# Altium schematic sheet PS3604LRCF.SchDoc(PS3604LRCF)
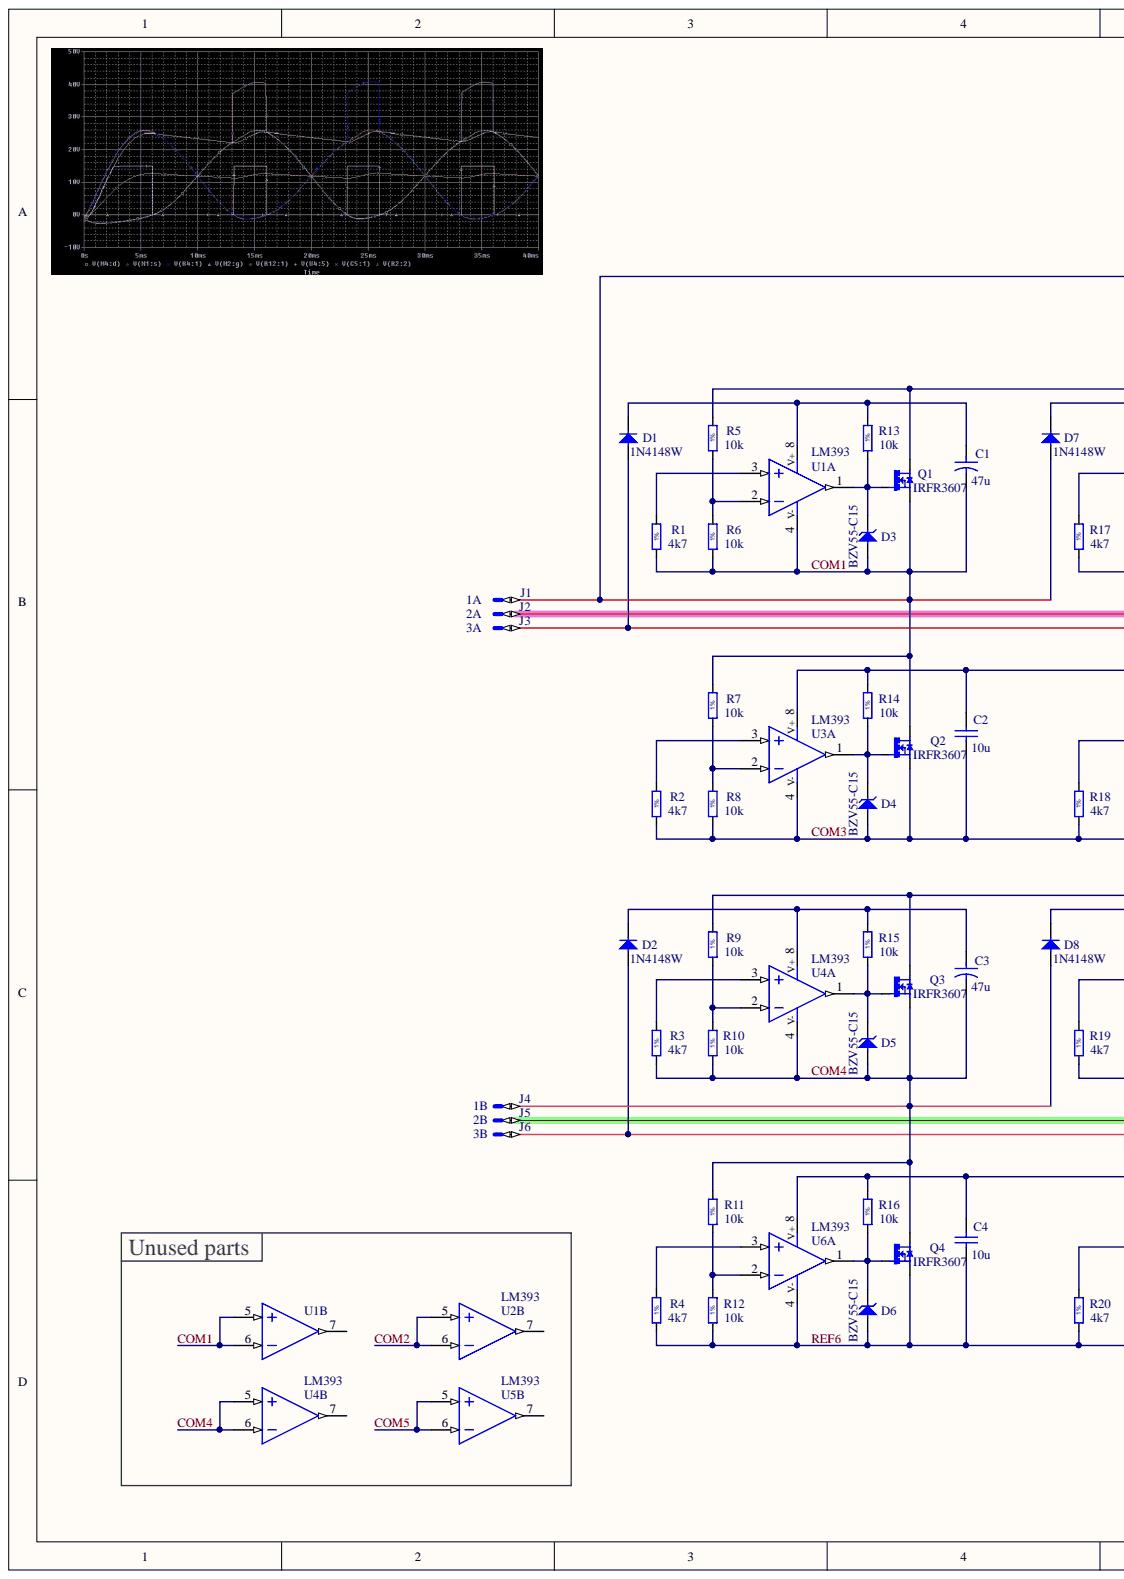
[diagram p0: PS3604LRCF.SchDoc, left]
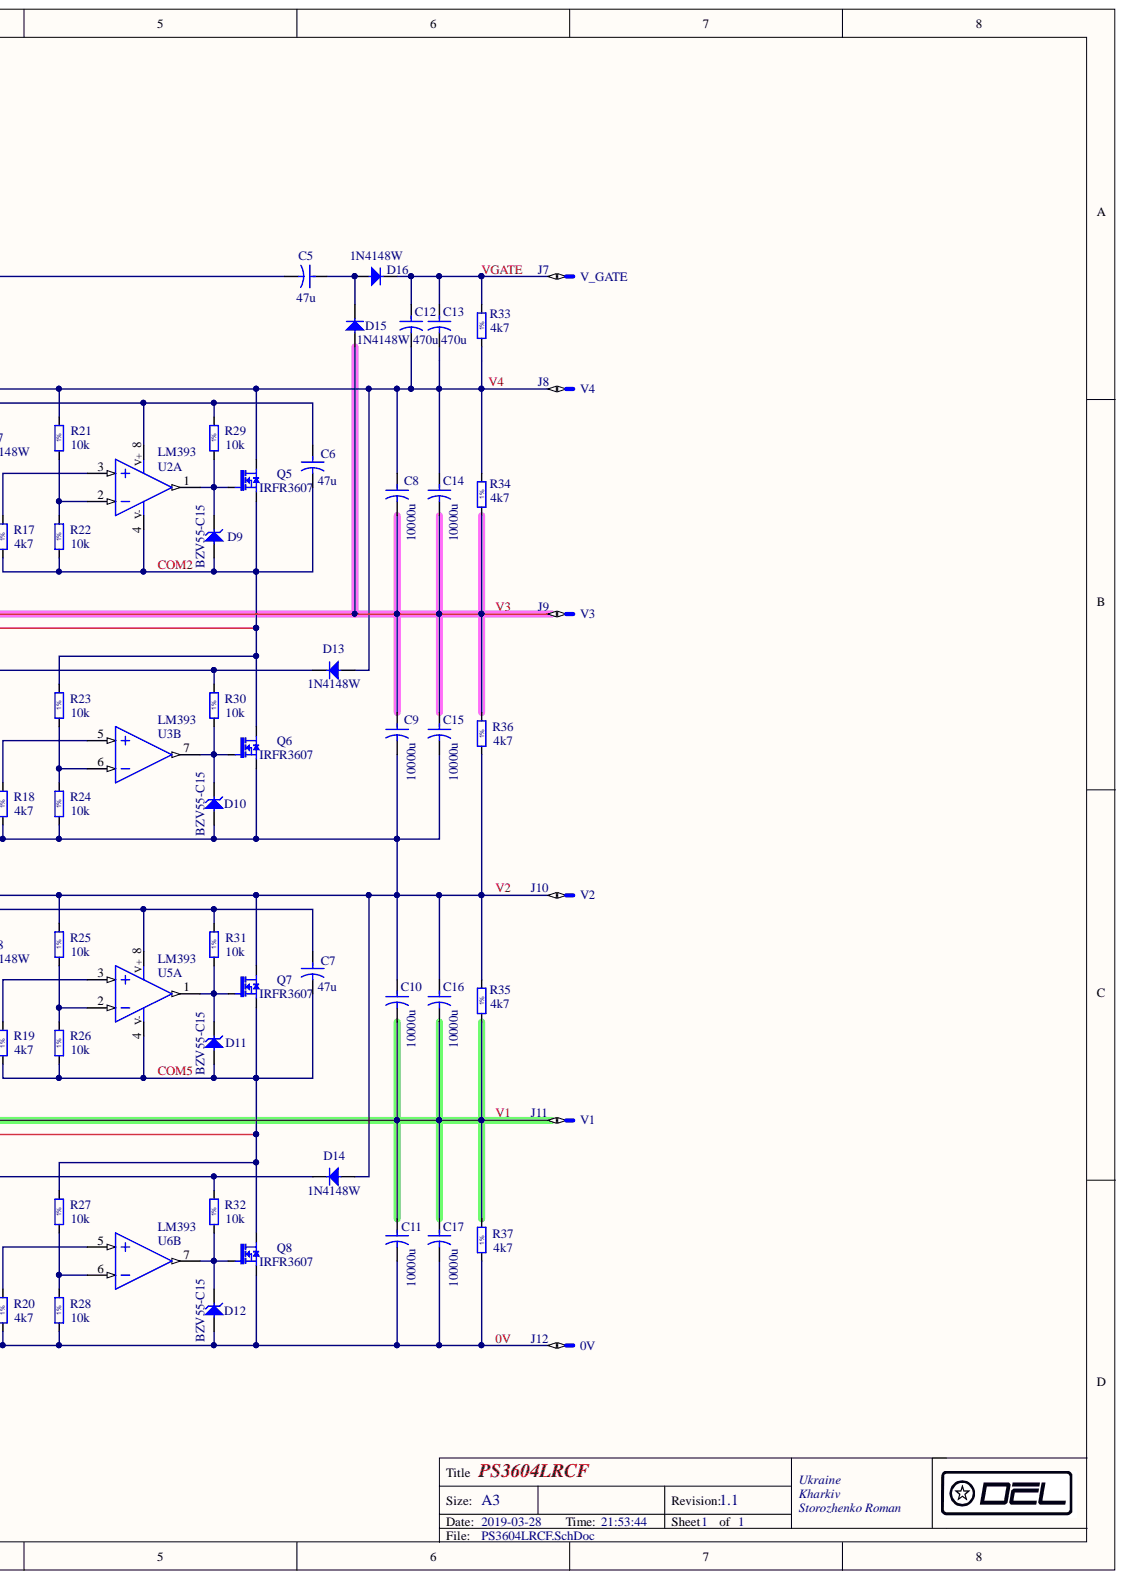
[diagram p1: PS3604LRCF.SchDoc, right]

## Parts
C1  47u  [CAPPR250-630X1120]
C2  10u  [CAPC2012X135N]
C3  47u  [CAPPR250-630X1120]
C4  10u  [CAPC2012X135N]
C5  47u  [CAPPR250-630X1120]
C6  47u  [CAPPR250-630X1120]
C7  47u  [CAPPR250-630X1120]
C8  10000u  [CAPPR750-1850X3750]
C9  10000u  [CAPPR750-1850X3750]
C10  10000u  [CAPPR750-1850X3750]
C11  10000u  [CAPPR750-1850X3750]
C12  470u  [CAPPR500-1050X2200]
C13  470u  [CAPPR500-1050X2200]
C14  10000u  [CAPPR750-1850X3750]
C15  10000u  [CAPPR750-1850X3750]
C16  10000u  [CAPPR750-1850X3750]
C17  10000u  [CAPPR750-1850X3750]
D1  1N4148W  [SOD3715X145N]
D2  1N4148W  [SOD3715X145N]
D3  BZV55-C15  [DIOMELF3515N]
D4  BZV55-C15  [DIOMELF3515N]
D5  BZV55-C15  [DIOMELF3515N]
D6  BZV55-C15  [DIOMELF3515N]
D7  1N4148W  [SOD3715X145N]
D8  1N4148W  [SOD3715X145N]
D9  BZV55-C15  [DIOMELF3515N]
D10  BZV55-C15  [DIOMELF3515N]
D11  BZV55-C15  [DIOMELF3515N]
D12  BZV55-C15  [DIOMELF3515N]
D13  1N4148W  [SOD3715X145N]
D14  1N4148W  [SOD3715X145N]
D15  1N4148W  [SOD3715X145N]
D16  1N4148W  [SOD3715X145N]
J1  Quixon™  [MOLEX_19_70_54203]  (value not drawn)
J2  Quixon™  [MOLEX_19_70_54203]  (value not drawn)
J3  Quixon™  [MOLEX_19_70_54203]  (value not drawn)
J4  Quixon™  [MOLEX_19_70_54203]  (value not drawn)
J5  Quixon™  [MOLEX_19_70_54203]  (value not drawn)
J6  Quixon™  [MOLEX_19_70_54203]  (value not drawn)
J7  Quixon™  [MOLEX_19_70_54203]  (value not drawn)
J8  Quixon™  [MOLEX_19_70_54203]  (value not drawn)
J9  Quixon™  [MOLEX_19_70_54203]  (value not drawn)
J10  Quixon™  [MOLEX_19_70_54203]  (value not drawn)
J11  Quixon™  [MOLEX_19_70_54203]  (value not drawn)
J12  Quixon™  [MOLEX_19_70_54203]  (value not drawn)
Q1  IRFR3607  [TO228P991X239-3N-R546X521]
Q2  IRFR3607  [TO228P991X239-3N-R546X521]
Q3  IRFR3607  [TO228P991X239-3N-R546X521]
Q4  IRFR3607  [TO228P991X239-3N-R546X521]
Q5  IRFR3607  [TO228P991X239-3N-R546X521]
Q6  IRFR3607  [TO228P991X239-3N-R546X521]
Q7  IRFR3607  [TO228P991X239-3N-R546X521]
Q8  IRFR3607  [TO228P991X239-3N-R546X521]
R1  4k7  [RESC1608X55N]
R2  4k7  [RESC1608X55N]
R3  4k7  [RESC1608X55N]
R4  4k7  [RESC1608X55N]
R5  10k  [RESC1608X55N]
R6  10k  [RESC1608X55N]
R7  10k  [RESC1608X55N]
R8  10k  [RESC1608X55N]
R9  10k  [RESC1608X55N]
R10  10k  [RESC1608X55N]
R11  10k  [RESC1608X55N]
R12  10k  [RESC1608X55N]
R13  10k  [RESC1608X55N]
R14  10k  [RESC1608X55N]
R15  10k  [RESC1608X55N]
R16  10k  [RESC1608X55N]
R17  4k7  [RESC1608X55N]
R18  4k7  [RESC1608X55N]
R19  4k7  [RESC1608X55N]
R20  4k7  [RESC1608X55N]
R21  10k  [RESC1608X55N]
R22  10k  [RESC1608X55N]
R23  10k  [RESC1608X55N]
R24  10k  [RESC1608X55N]
R25  10k  [RESC1608X55N]
R26  10k  [RESC1608X55N]
R27  10k  [RESC1608X55N]
R28  10k  [RESC1608X55N]
R29  10k  [RESC1608X55N]
R30  10k  [RESC1608X55N]
R31  10k  [RESC1608X55N]
R32  10k  [RESC1608X55N]
R33  4k7  [RESC1608X55N]
R34  4k7  [RESC1608X55N]
R35  4k7  [RESC1608X55N]
R36  4k7  [RESC1608X55N]
R37  4k7  [RESC1608X55N]
U1  LM393  [SOIC127P600X175-8N]  (parts A,B)
U2  LM393  [SOIC127P600X175-8N]  (parts A,B)
U3  LM393  [SOIC127P600X175-8N]  (parts A,B)
U4  LM393  [SOIC127P600X175-8N]  (parts A,B)
U5  LM393  [SOIC127P600X175-8N]  (parts A,B)
U6  LM393  [SOIC127P600X175-8N]  (parts A,B)

## Nets
0V: C4.1 C11.2 C17.2 D6.2 D12.2 J12.1 Q4.2 Q8.2 R4.1 R12.1 R20.1 R28.1 R37.1 U6.4(V-)
COM1: C1.2 C5.2 D3.2 D7.2 J1.1 Q1.2 Q2.3 R1.1 R6.1 R7.2 U1.4 U1.5 U1.6
COM2: C6.2 D1.2 D9.2 J3.1 Q5.2 Q6.3 R17.1 R22.1 R23.2 U2.4 U2.5 U2.6
COM3: C2.1 C9.2 C10.1 C15.2 C16.1 D4.2 D10.2 D14.2 J10.1 Q2.2 Q3.3 Q6.2 Q7.3 R2.1 R8.1 R9.2 R18.1 R24.1 R25.2 R35.2 R36.1 U3.4(V-)
COM4: C3.2 D5.2 D8.2 J4.1 Q3.2 Q4.3 R3.1 R10.1 R11.2 U4.4 U4.5 U4.6
COM5: C7.2 D2.2 D11.2 J6.1 Q7.2 Q8.3 R19.1 R26.1 R27.2 U5.4 U5.5 U5.6
V1: C10.2 C11.1 C16.2 C17.1 J5.1 J11.1 R35.1 R37.2
V3: C8.2 C9.1 C14.2 C15.1 D15.2 J2.1 J9.1 R34.1 R36.2
V4: C8.1 C12.2 C13.2 C14.1 D13.2 J8.1 Q1.3 Q5.3 R5.2 R21.2 R33.1 R34.2
VGATE: C12.1 C13.1 D16.1 J7.1 R33.2
NetC1_1: C1.1 D1.1 R13.2 U1.8
NetC2_2: C2.2 D13.1 R14.2 R30.2 U3.8
NetC3_1: C3.1 D2.1 R15.2 U4.8
NetC4_2: C4.2 D14.1 R16.2 R32.2 U6.8
NetC5_1: C5.1 D15.1 D16.2
NetC6_1: C6.1 D7.1 R29.2 U2.8
NetC7_1: C7.1 D8.1 R31.2 U5.8
NetD3_1: D3.1 Q1.1 R13.1 U1.1
NetD4_1: D4.1 Q2.1 R14.1 U3.1
NetD5_1: D5.1 Q3.1 R15.1 U4.1
NetD6_1: D6.1 Q4.1 R16.1 U6.1
NetD9_1: D9.1 Q5.1 R29.1 U2.1
NetD10_1: D10.1 Q6.1 R30.1 U3.7
NetD11_1: D11.1 Q7.1 R31.1 U5.1
NetD12_1: D12.1 Q8.1 R32.1 U6.7
NetR1_2: R1.2 U1.3
NetR2_2: R2.2 U3.3
NetR3_2: R3.2 U4.3
NetR4_2: R4.2 U6.3
NetR5_1: R5.1 R6.2 U1.2
NetR7_1: R7.1 R8.2 U3.2
NetR9_1: R9.1 R10.2 U4.2
NetR11_1: R11.1 R12.2 U6.2
NetR17_2: R17.2 U2.3
NetR18_2: R18.2 U3.5
NetR19_2: R19.2 U5.3
NetR20_2: R20.2 U6.5
NetR21_1: R21.1 R22.2 U2.2
NetR23_1: R23.1 R24.2 U3.6
NetR25_1: R25.1 R26.2 U5.2
NetR27_1: R27.1 R28.2 U6.6

Not connected: U1 7; U2 7; U4 7; U5 7

## Library data of the parts
C1 C3 C5-C7 = CAER_47UF_50V_20%_EXR470M50B: Polarised capacitor; Part Description: Aluminum Electrolytic Capacitor (EXR Serie); Manufacturer: HITANO; Voltage: 50V; Tolerance: ±20%; Database Table Name: Capacitors THD; Value: 47u; Status: None; Socket: No; SMD: No; Pin Count: 2; Part Number: CAER_47UF_50V_20%_EXR470M50B; Mounted: Yes; Manufacturer Part Number: EXR470M50B; Library Name: Capacitors.DbLib; LatestRevisionDate: 09.03.2018; CreateDate: 09.03.2018; Component Type: Standard; Component Kind: Standard; ComponentHeight: 11mm; Case: LS2.5 D6.3 x H11; Author: DEL
C2 C4 = CC0805_10UF_16V_10%_X7R: Non-polarised capacitor; Part Description: SMD Multilayer Chip Ceramic Capacitor; Manufacturer1 Part Number: C0805C106K4PAC; Manufacturer: GENERIC; Voltage: 16V; Tolerance: ±10%; TC: X7R; Database Table Name: Capacitors SMD; Value: 10u; Status: Preferred; Socket: No; SMD: Yes; Pin Count: 2; Part Number: CC0805_10UF_16V_10%_X7R; Mounted: Yes; Manufacturer Part Number: CC0805_10UF_16V_10%_X7R; Manufacturer1 Example: KEMET; Manufacturer1 ComponentHeight: 1.4mm; Library Name: Capacitors.DbLib; LatestRevisionDate: 14.04.2016; HelpURL: \\cern.ch\dfs\Applications\Altium\Datasheets\CC1206_X5R_KEMET.pdf; CreateDate: 14.04.2016; Component Type: Standard; Component Kind: Standard; Case: 0805; Author: ELMAN
C8-C11 C14-C17 = CAER_10000UF_16V_20%_EXR103M16B: Polarised capacitor; Part Description: Aluminum Electrolytic Capacitor (EXR Serie); Manufacturer: HITANO; Voltage: 16V; Tolerance: ±20%; Database Table Name: Capacitors THD; Value: 10000u; Status: None; Socket: No; SMD: No; Pin Count: 2; Part Number: CAER_10000UF_16V_20%_EXR103M16B; Mounted: Yes; Manufacturer Part Number: EXR103M16B; Library Name: Capacitors.DbLib; LatestRevisionDate: 09.03.2018; CreateDate: 09.03.2018; Component Type: Standard; Component Kind: Standard; ComponentHeight: 36mm; Case: LS7.5 D18 x H36; Author: DEL
C12 C13 = CAER_470UF_35V_20%_EXR471M35B: Polarised capacitor; Part Description: Aluminum Electrolytic Capacitor (EXR Serie); Manufacturer: HITANO; Voltage: 36V; Tolerance: ±20%; Database Table Name: Capacitors THD; Value: 470u; Status: None; Socket: No; SMD: No; Pin Count: 2; Part Number: CAER_470UF_35V_20%_EXR471M35B; Mounted: Yes; Manufacturer Part Number: EXR471M35B; Library Name: Capacitors.DbLib; LatestRevisionDate: 09.03.2018; CreateDate: 09.03.2018; Component Type: Standard; Component Kind: Standard; ComponentHeight: 21mm; Case: LS5 D10 x H21; Author: DEL
D1 D2 D7 D8 D13-D16 = 1N4148W: Diode; Part Description: Small Signal Diode; Manufacturer Part Number: 1N4148W-E3-08; Manufacturer: VISHAY SEMICONDUCTORS; Voltage: 75V; Power: 150mA; Database Table Name: Diodes; Socket: No; SMD: Yes; Pin Count: 2; Part Number: 1N4148W; PackageDescription: SOD Diode (SOD-123); 2.70mm W X 1.55mm L X 1.45mm H body, IPC Medium Density; Mounted: Yes; Library Name: ICs And Semiconductors.DbLib; LatestRevisionDate: 27.01.2018; Device: 1N4148W; CreateDate: 27.01.2018; Component Type: Standard; Component Kind: Standard; ComponentHeight: 1.45mm; Case: SOD-123; Author: DEL
D3-D6 D9-D12 = BZV55-C15: Zener Diode; Part Description: Voltage Regulator Diode; Manufacturer: PHILIPS NXP; Voltage: 15V; Power: 500mW; Database Table Name: Diodes; Socket: No; SMD: Yes; Pin Count: 2; Part Number: BZV55-C15; PackageDescription: SOD80C, MELF Diode, 2-Leads, Body 3.5x1.5mm, IPC Medium Density; Mounted: Yes; Manufacturer Part Number: BZV55-C15; Library Name: ICs And Semiconductors.DbLib; LatestRevisionDate: 06.05.2008; HelpURL: \\cern.ch\dfs\Applications\Altium\Datasheets\BZV55.pdf; Device: BZV55-C15; CreateDate: 06.05.2008; Component Type: Standard; Component Kind: Standard; ComponentHeight: 1.6mm; Case: SOD-80C; Author: CERN DEM JLC
J1-J12 = MOLEX_19_70_54203: Single Pin Male; Part Description: 0.250" (6.35mm) Quick Connect Male Solder Connector Non-Insulated; Manufacturer: MOLEX; Status: None; Socket: No; SMD: No; Pin Count: 1; Part Number: MOLEX_19_70_54203; Mounted: Yes; Manufacturer Part Number: 19_70_54203; Library Name: Connectors.DbLib; LatestRevisionDate: 09.03.2018; Family: Quixon™; Database Table Name: MOLEX; CreateDate: 09.03.2018; Component Type: Standard; Component Kind: Standard; ComponentHeight: 10.29mm; Author: DEL
Q1-Q8 = IRFR3607PbF: N-CHANNEL MOSFET WITH DIODE; Part Description: 75V 56A 7.34 mOhm N-Channel; Manufacturer: INTERNATIONAL RECTIFIER; Database Table Name: Transistors; Socket: No; SMD: Yes; Pin Count: 3; Part Number: IRFR3607PbF; PackageDescription: TO-252AA DPAK TO, 2.28mm pitch; 2 pin,9.905mm L X 6.095mm W X 2.39mm H body, IPC Medium Density; Mounted: Yes; Manufacturer Part Number: IRFR3607PbF; Library Name: ICs And Semiconductors.DbLib; LatestRevisionDate: 09.03.2018; Device: IRFR3607; CreateDate: 09.03.2018; Component Type: Standard; Component Kind: Standard; ComponentHeight: 2.39mm; Case: DPAK; Author: DEL
R1-R4 R17-R20 R33-R37 = R0603_4K7_1%_0.1W_100PPM: Resistor - 1%; Part Description: General Purpose Thick Film Chip Resistor; Manufacturer1 Part Number: NRC06F4701TRF; Manufacturer: GENERIC; TC: ±100ppm/°C; Power: 0.1W; Database Table Name: Resistors SMD; Value: 4k7; Tolerance: ±1%; Status: Preferred; Socket: No; SMD: Yes; Pin Count: 2; Part Number: R0603_4K7_1%_0.1W_100PPM; Mounted: Yes; Manufacturer Part Number: R0603_4K7_1%_0.1W_100PPM; Manufacturer1 Example: NIC COMPONENT; Manufacturer1 ComponentHeight: 0.55mm; Library Name: Resistors.DbLib; LatestRevisionDate: 13.03.2008; HelpURL: \\cern.ch\dfs\Applications\Altium\Datasheets\R0603_NIC_NRC.pdf; CreateDate: 03.12.2007; Component Type: Standard; Component Kind: Standard; Case: 0603; Author: CERN DEM JLC
R5-R16 R21-R32 = R0603_10K_1%_0.1W_100PPM: Resistor - 1%; Part Description: General Purpose Thick Film Chip Resistor; Manufacturer1 Part Number: NRC06F1002TRF; Manufacturer: GENERIC; TC: ±100ppm/°C; Power: 0.1W; Database Table Name: Resistors SMD; Value: 10k; Tolerance: ±1%; Status: Preferred; Socket: No; SMD: Yes; Pin Count: 2; Part Number: R0603_10K_1%_0.1W_100PPM; Mounted: Yes; Manufacturer Part Number: R0603_10K_1%_0.1W_100PPM; Manufacturer1 Example: NIC COMPONENT; Manufacturer1 ComponentHeight: 0.55mm; Library Name: Resistors.DbLib; LatestRevisionDate: 13.03.2008; HelpURL: \\cern.ch\dfs\Applications\Altium\Datasheets\R0603_NIC_NRC.pdf; CreateDate: 03.12.2007; Component Type: Standard; Component Kind: Standard; Case: 0603; Author: CERN DEM JLC
U1-U6 = LM393M/NOPB: Dual Operational Amplifier; Part Description: Low Power Low Offset Voltage Dual Comparators; Manufacturer: NATIONAL SEMICONDUCTOR; Database Table Name: Operational Amplifiers; Socket: No; SMD: Yes; Pin Count: 8; Part Number: LM393M/NOPB; PackageDescription: SOIC 8, Pitch 1.27mm - Body 4x5mm, IPC Medium Density; Mounted: Yes; Manufacturer Part Number: LM393M/NOPB; Library Name: ICs And Semiconductors.DbLib; LatestRevisionDate: 18.11.2011; HelpURL: \\cern.ch\dfs\Applications\Altium\Datasheets\LM393M-NOPB.pdf; Device: LM393; CreateDate: 18.11.2011; Component Type: Standard; Component Kind: Standard; ComponentHeight: 1.75mm; Case: SOIC8; Author: CERN DEM JLC
